# Altium schematic sheet woda.SchDoc(woda)
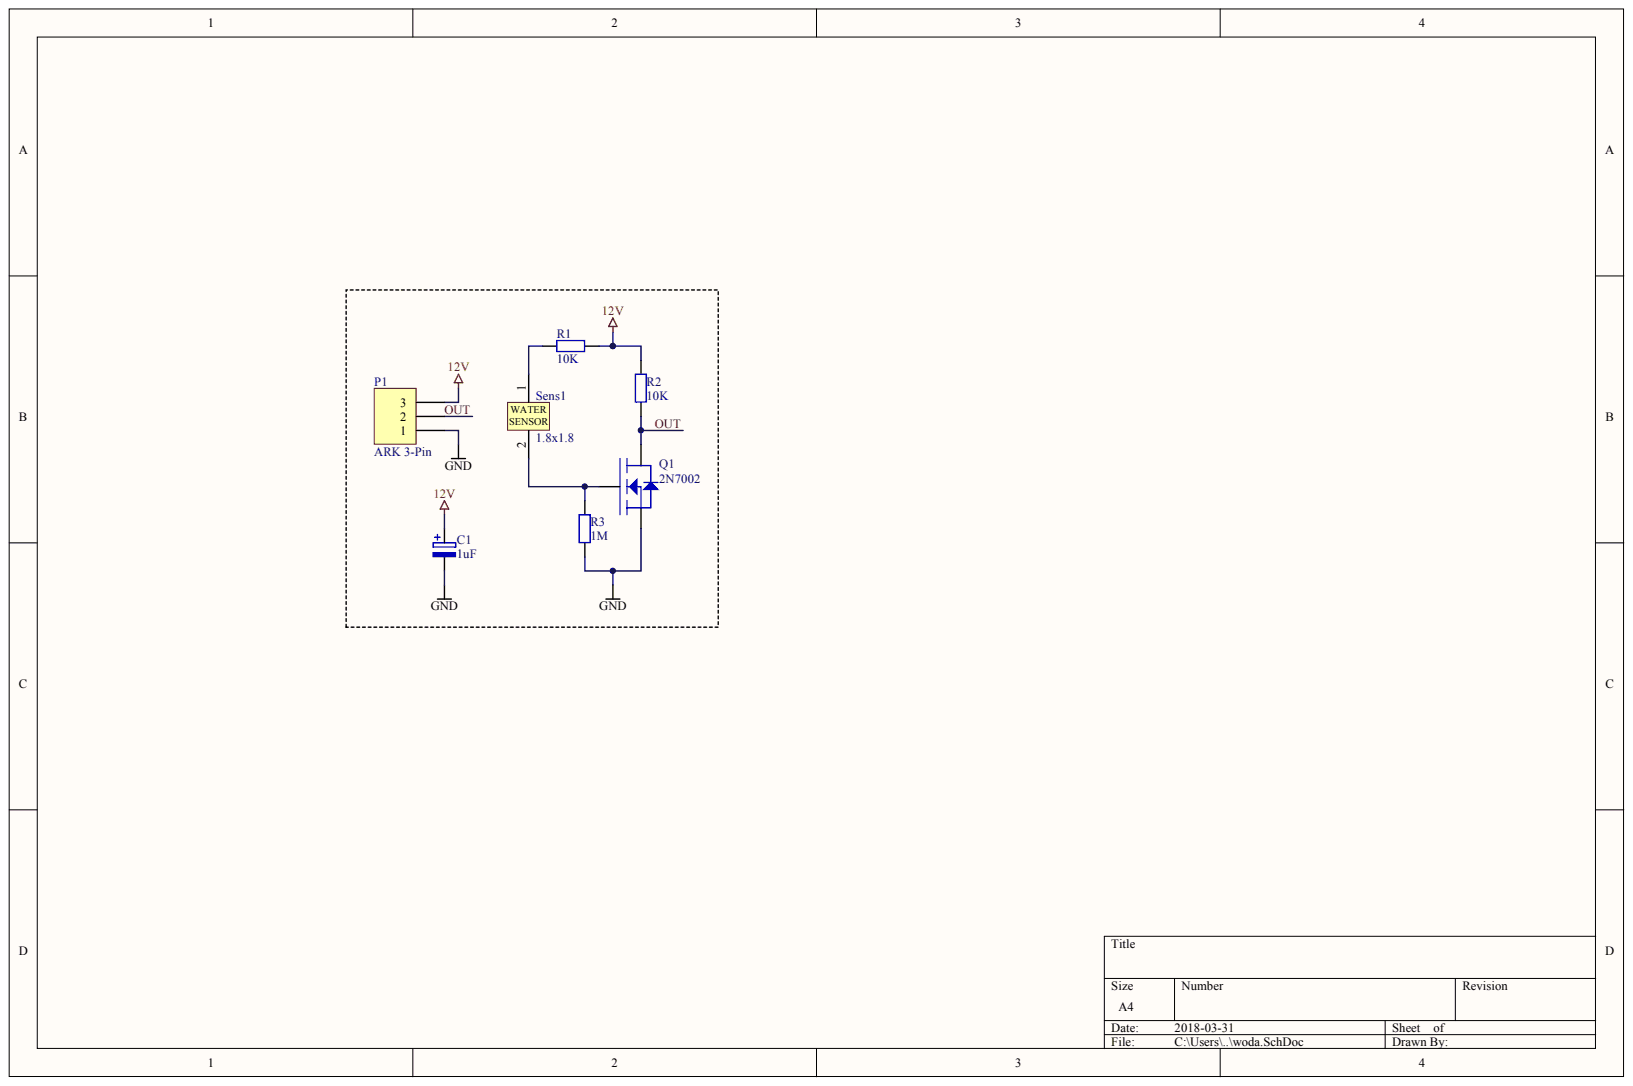
[diagram p0: woda.SchDoc]

## Parts
C1  1uF
P1  ARK 3-Pin
Q1  2N7002
R1  10K
R2  10K
R3  1M
Sens1  1.8x1.8

## Nets
12V: C1.1 P1.3 R1.1 R2.2
GND: C1.2 P1.1 Q1.2 R3.1
OUT: P1.2 Q1.3 R2.1
NetQ1_1: Q1.1 R3.2 Sens1.2
NetR1_2: R1.2 Sens1.1
